# Altium schematic sheet nfb.SchDoc
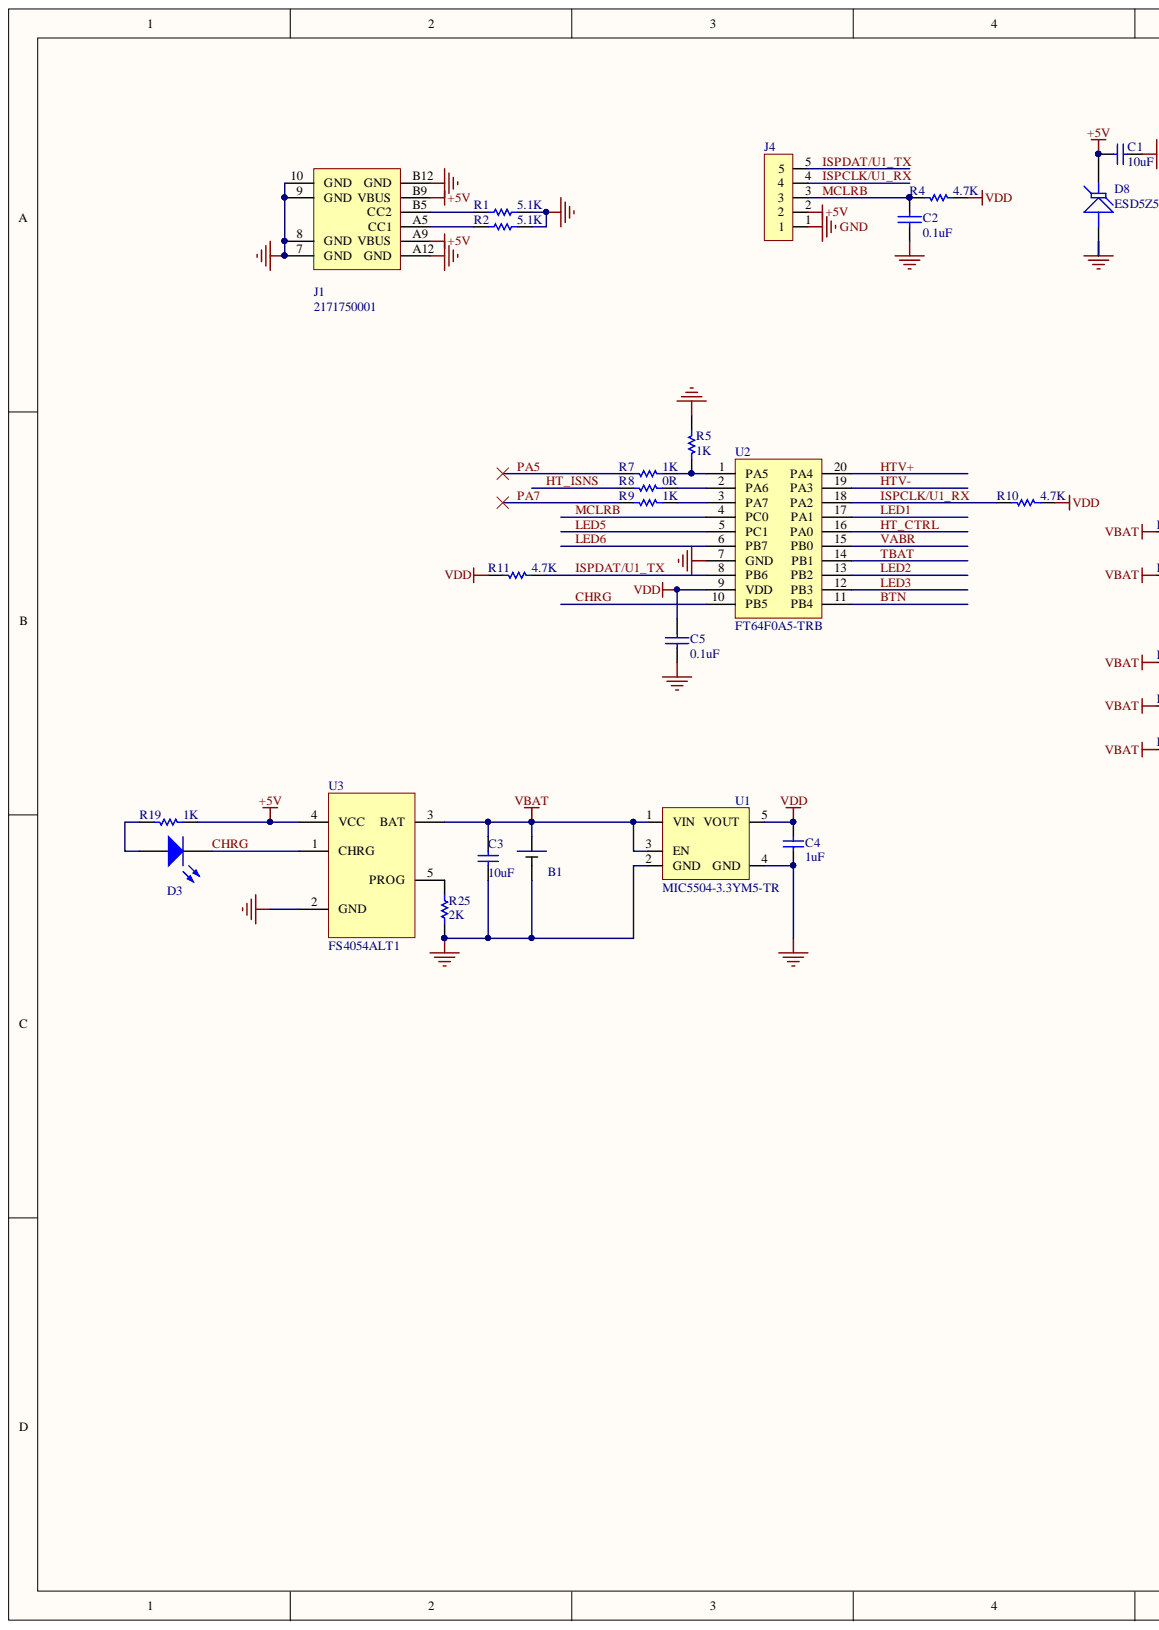
[diagram p0: nfb.SchDoc, left]
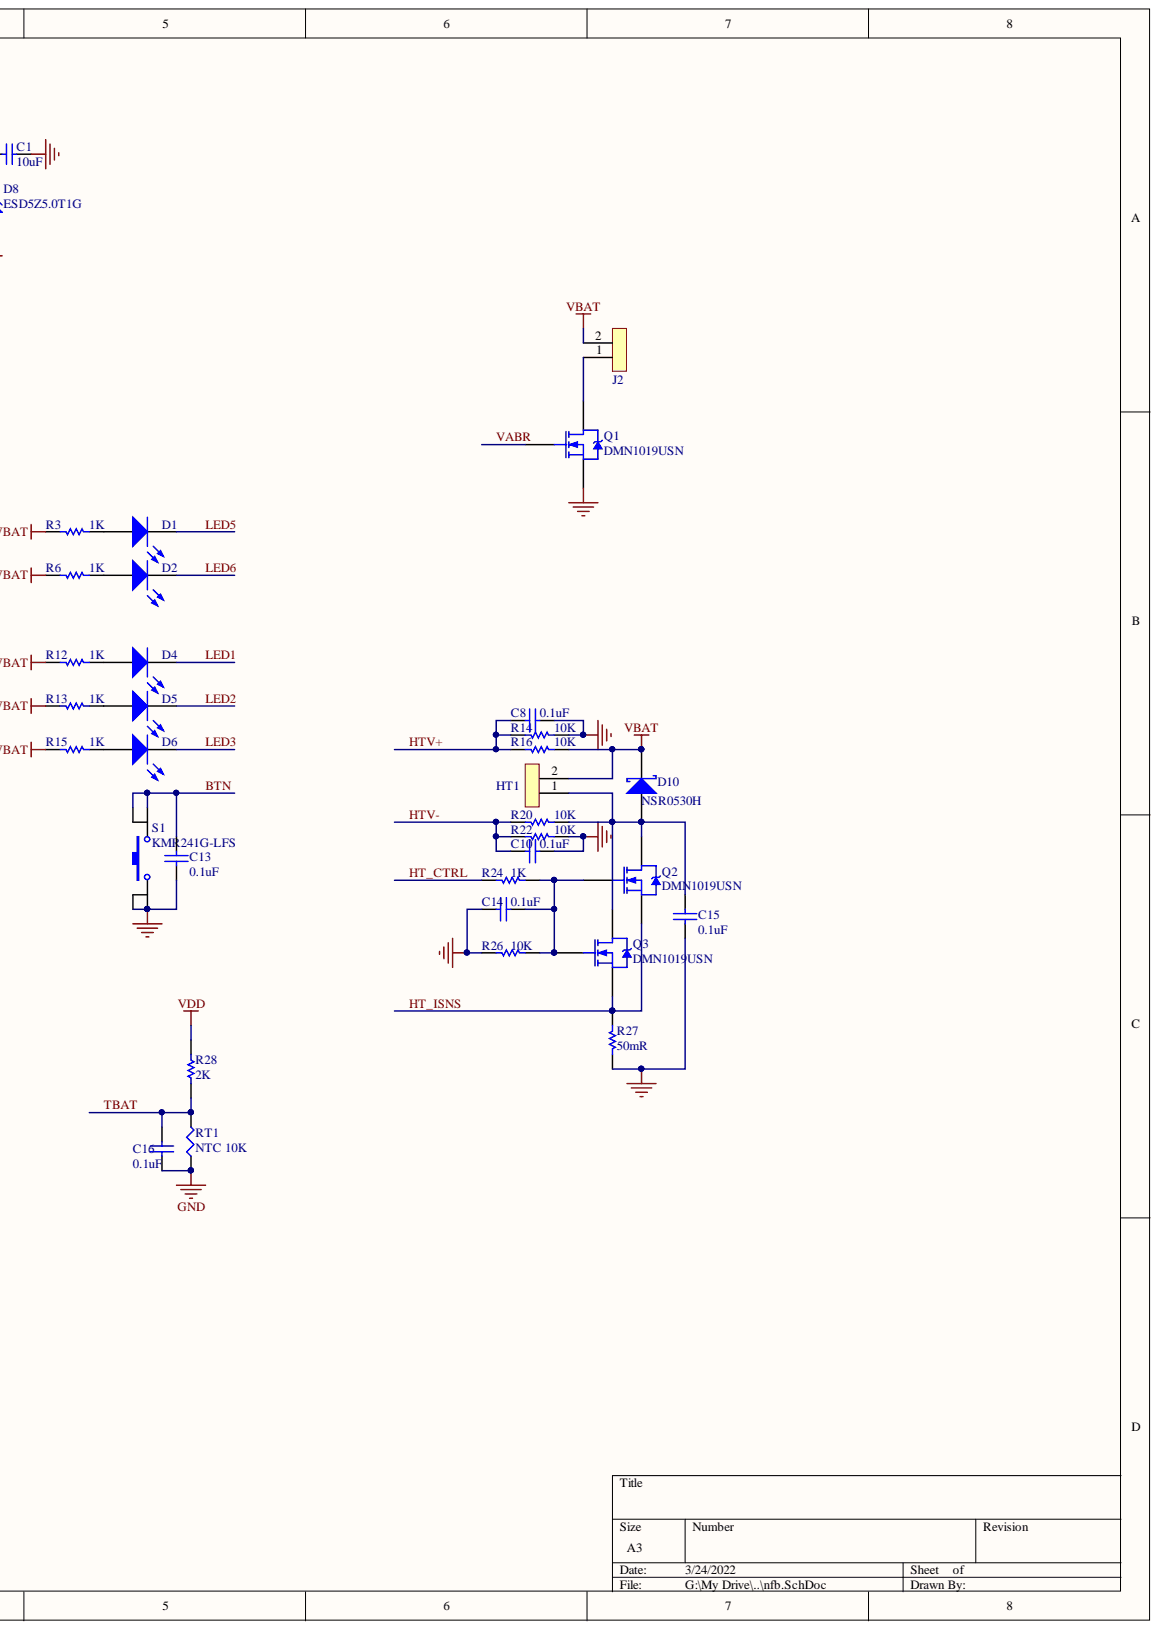
[diagram p1: nfb.SchDoc, right]

## Parts
B1  1043P  [bat1]  (value not drawn)
C1  10uF  [CAP0603]
C2  0.1uF  [C0402]
C3  10uF  [CAP0603]
C4  1uF  [CAP0603]
C5  0.1uF  [C0402]
C8  0.1uF  [C0402]
C10  0.1uF  [C0402]
C13  0.1uF  [CAP0402]
C14  0.1uF  [C0402]
C15  0.1uF  [C0402]
C16  0.1uF  [C0402]
D1  LG Q971-KN-1  [LED0603]  (value not drawn)
D2  LG Q971-KN-1  [LED0603]  (value not drawn)
D3  LG Q971-KN-1  [LED0603]  (value not drawn)
D4  LG Q971-KN-1  [LED0603]  (value not drawn)
D5  LG Q971-KN-1  [LED0603]  (value not drawn)
D6  LG Q971-KN-1  [LED0603]  (value not drawn)
D8  ESD5Z5.0T1G  [SOD523]
D10  NSR0530H  [SOD323]
HT1  Heater  [heater]  (value not drawn)
J1  2171750001  [2171750001]
J2  PH2.54_2P  [PH1.27_2X1]  (value not drawn)  (no BOM)
J4  (no value)  [ISP_PAD]
Q1  DMN1019USN  [SC59]
Q2  DMN1019USN  [SC59]
Q3  DMN1019USN  [SC59]
R1  5.1K  [RES0402]
R2  5.1K  [RES0402]
R3  1K  [RES0402]
R4  4.7K  [RES0402]
R5  1K  [RES0402]
R6  1K  [RES0402]
R7  1K  [RES0402]
R8  0R  [RES0402]
R9  1K  [RES0402]
R10  4.7K  [RES0402]
R11  4.7K  [RES0402]
R12  1K  [RES0402]
R13  1K  [RES0402]
R14  10K  [RES0402]
R15  1K  [RES0402]
R16  10K  [RES0402]
R19  1K  [RES0402]
R20  10K  [RES0402]
R22  10K  [RES0402]
R24  1K  [RES0402]
R25  2K  [RES0402]
R26  10K  [RES0402]
R27  50mR  [RES0805]
R28  2K  [RES0402]
RT1  NTC 10K  [RES0603]
S1  KMR241G-LFS  [KMR]
U1  MIC5504-3.3YM5-TR  [SOT23-5]
U2  FT64F0A5-TRB  [TSSOP20]
U3  FS4054ALT1  [SOT23-5]

## Nets
+5V: C1.2 D8.2 J1.A9(VBUS) J1.B9(VBUS) J4.2 R19.2 U3.4(VCC)
GND: B1.1 C1.1 C2.1 C3.2 C4.1 C5.1 C8.1 C10.1 C13.1 C14.2 C15.1 C16.1 D8.1 J1.7(GND) J1.8(GND) J1.9(GND) J1.10(GND) J1.A12(GND) J1.B12(GND) J4.1 Q1.2 R1.2 R2.2 R5.2 R14.2 R22.2 R25.2 R26.1 R27.1 RT1.1 S1.1 S1.4 U1.2(GND) U1.4(GND) U2.7(GND) U3.2(GND)
VBAT: B1.2 C3.1 D10.2 HT1.2 J2.2 R3.1 R6.1 R12.1 R13.1 R15.1 R16.2 U1.1(VIN) U1.3(EN) U3.3(BAT)
VDD: C4.2 C5.2 R4.2 R10.2 R11.1 R28.1 U1.5(VOUT) U2.9(VDD)
BTN: C13.2 S1.2 S1.3 U2.11(PB4)
CHRG: D3.2 U2.10(PB5) U3.1(CHRG)
HT_CTRL: R24.1 U2.16(PA0)
HT_ISNS: Q2.2 Q3.2 R8.1 R27.2
HTV+: C8.2 R14.1 R16.1 U2.20(PA4)
HTV-: C10.2 R20.1 R22.1 U2.19(PA3)
ISPCLK/U1_RX: J4.4 R10.1 U2.18(PA2)
ISPDAT/U1_TX: J4.5 R11.2 U2.8(PB6)
LED1: D4.2 U2.17(PA1)
LED2: D5.2 U2.13(PB2)
LED3: D6.2 U2.12(PB3)
LED5: D1.2 U2.5(PC1)
LED6: D2.2 U2.6(PB7)
MCLRB: C2.2 J4.3 R4.1 U2.4(PC0)
PA5: R7.1
PA7: R9.1
TBAT: C16.2 R28.2 RT1.2 U2.14(PB1)
VABR: Q1.1 U2.15(PB0)
NetC14_1: C14.1 Q2.1 Q3.1 R24.2 R26.2
NetC15_2: C15.2 D10.1 HT1.1 Q2.3 Q3.3 R20.2
NetD1_1: D1.1 R3.2
NetD2_1: D2.1 R6.2
NetD3_1: D3.1 R19.1
NetD4_1: D4.1 R12.2
NetD5_1: D5.1 R13.2
NetD6_1: D6.1 R15.2
NetJ1_A5: J1.A5(CC1) R2.1
NetJ1_B5: J1.B5(CC2) R1.1
NetJ2_1: J2.1 Q1.3
NetR5_1: R5.1 R7.2 U2.1(PA5)
NetR8_2: R8.2 U2.2(PA6)
NetR9_2: R9.2 U2.3(PA7)
NetR25_1: R25.1 U3.5(PROG)

## Library data of the parts
B1 = 18650: Single Cell Battery Holder; Manufacturer: KEYSTONE; Component Kind: Standard; PartNumber: 1043P; Library Name: sku2.SCHLIB
C1 = CAP1: Ceramic Cap; Tolerance: 10%; Voltage Rating: 6.3V; Component Kind: Standard; Library Name: multiplexer.SchLib; Value: 10uF
C2 C5 C8 C10 C13-C16 = CAP: Component Kind: Standard; Description: CAP; Value: 0.1uF; Library Name: Liaw.SchLib
C3 C4 = CAP1: Ceramic Cap; Tolerance: 10%; Voltage Rating: 50V; Component Kind: Standard
  C3: Library Name: multiplexer.SchLib; Value: 10uF
  C4: Value: 1uF; Library Name: prospace.SCHLIB
D1-D6 = LED2: Typical RED, GREEN, YELLOW, AMBER GaAs LED; Manufacturer: OSRAM; Component Kind: Standard; Library Name: Standard.SchLib; PartNumber: LG Q971-KN-1
D8 = Tranzorb: Transient Voltage Suppression (TVS) Diode; Component Kind: Standard; LatestRevisionDate: 17-Jul-2002; LatestRevisionNote: Re-released for DXP Platform.; Library Name: Miscellaneous Devices.IntLib; PackageDescription: Axial Lead Diode; PackageReference: DO-7; Published: 24-Mar-1999; Publisher: Altium Limited
D10 = D Schottky: Schottky Diode; Code_JEDEC: DO-214-AA; Component Kind: Standard; LatestRevisionDate: 17-Jul-2002; LatestRevisionNote: Re-released for DXP Platform.; Library Name: Miscellaneous Devices.IntLib; PackageDescription: DO-214-AA/SMB; 2 C-Bend Leads; Body 5.3 x 3.6 mm, inc. leads (LxW); PackageReference: SMB; Published: 8-Jun-2000; Simulation: SKYDIODE; Publisher: Altium Limited
HT1 = Heater: 2.54MM SOLDER POINT 2P; Component Kind: Standard; PartNumber: Heater; Library Name: nfb.SchLib
J1 = 2171750001: USB C Receptacle; Manufacturer: Molex; Component Kind: Standard; PartNumber: 2171750001
J2 = PH2.54_2P: 2.54MM SOLDER POINT 2P; Library Name: nfb.SchLib
J4 = PH2.54_5X1: Component Kind: Standard; Library Name: sensorBLE.SCHLIB
Q1-Q3 = N-MOSFET: Component Kind: Standard; Description: N-MOSFET; Library Name: Liaw.SchLib
R1-R16 R19 R20 R22 R24-R28 = RES1: Chip Resistor; Tolerance: 1%; Component Kind: Standard
  R1 R2: Library Name: multiplexer.SchLib; Value: 5.1K
  R3 R5-R7 R9 R12 R13 R15 R19 R24: Value: 1K
  R4 R10 R11: Value: 4.7K
  R8: Value: 0R
  R14 R16 R20 R22 R26: Value: 10K
  R25 R28: Library Name: multiplexer.SchLib; Value: 2K
  R27: Value: 50mR
  R3-R16 R19 R20 R22 R24 R26 R27: Library Name: prospace.SCHLIB
RT1 = RES: Manufacturer: EPCOS; PartNumber: B57301V2103J060; Component Kind: Standard; Description: RES; Value: NTC 10K; Library Name: CYO.SCHLIB
S1 = SW-PB: Switch; Component Kind: Standard; LatestRevisionDate: 17-Jul-2002; LatestRevisionNote: Re-released for DXP Platform.; PackageDescription: Switch; 2 Leads; PackageReference: SPST-2; Published: 24-Mar-1999; Library Name: nfb2.SCHLIB; Publisher: Altium Limited
U1 = TPS78330DDCR: LDO, 3.3V 300mA; Manufacturer: Microchip; Component Kind: Standard; PartNumber: MIC5504-3.3YM5-TR; Library Name: prospace.SCHLIB
U2 = FT64F0A5-TRB: MCU; Manufacturer: FMD; Component Kind: Standard; PartNumber: FT64F0A5-TRB; Library Name: nfb.SchLib
U3 = FS4054A: Manufacturer: FOSAN; Component Kind: Standard; PartNumber: FS4054ALT1; Library Name: nfb.SchLib
